# Altium schematic sheet NanoD1_Audio.SchDoc
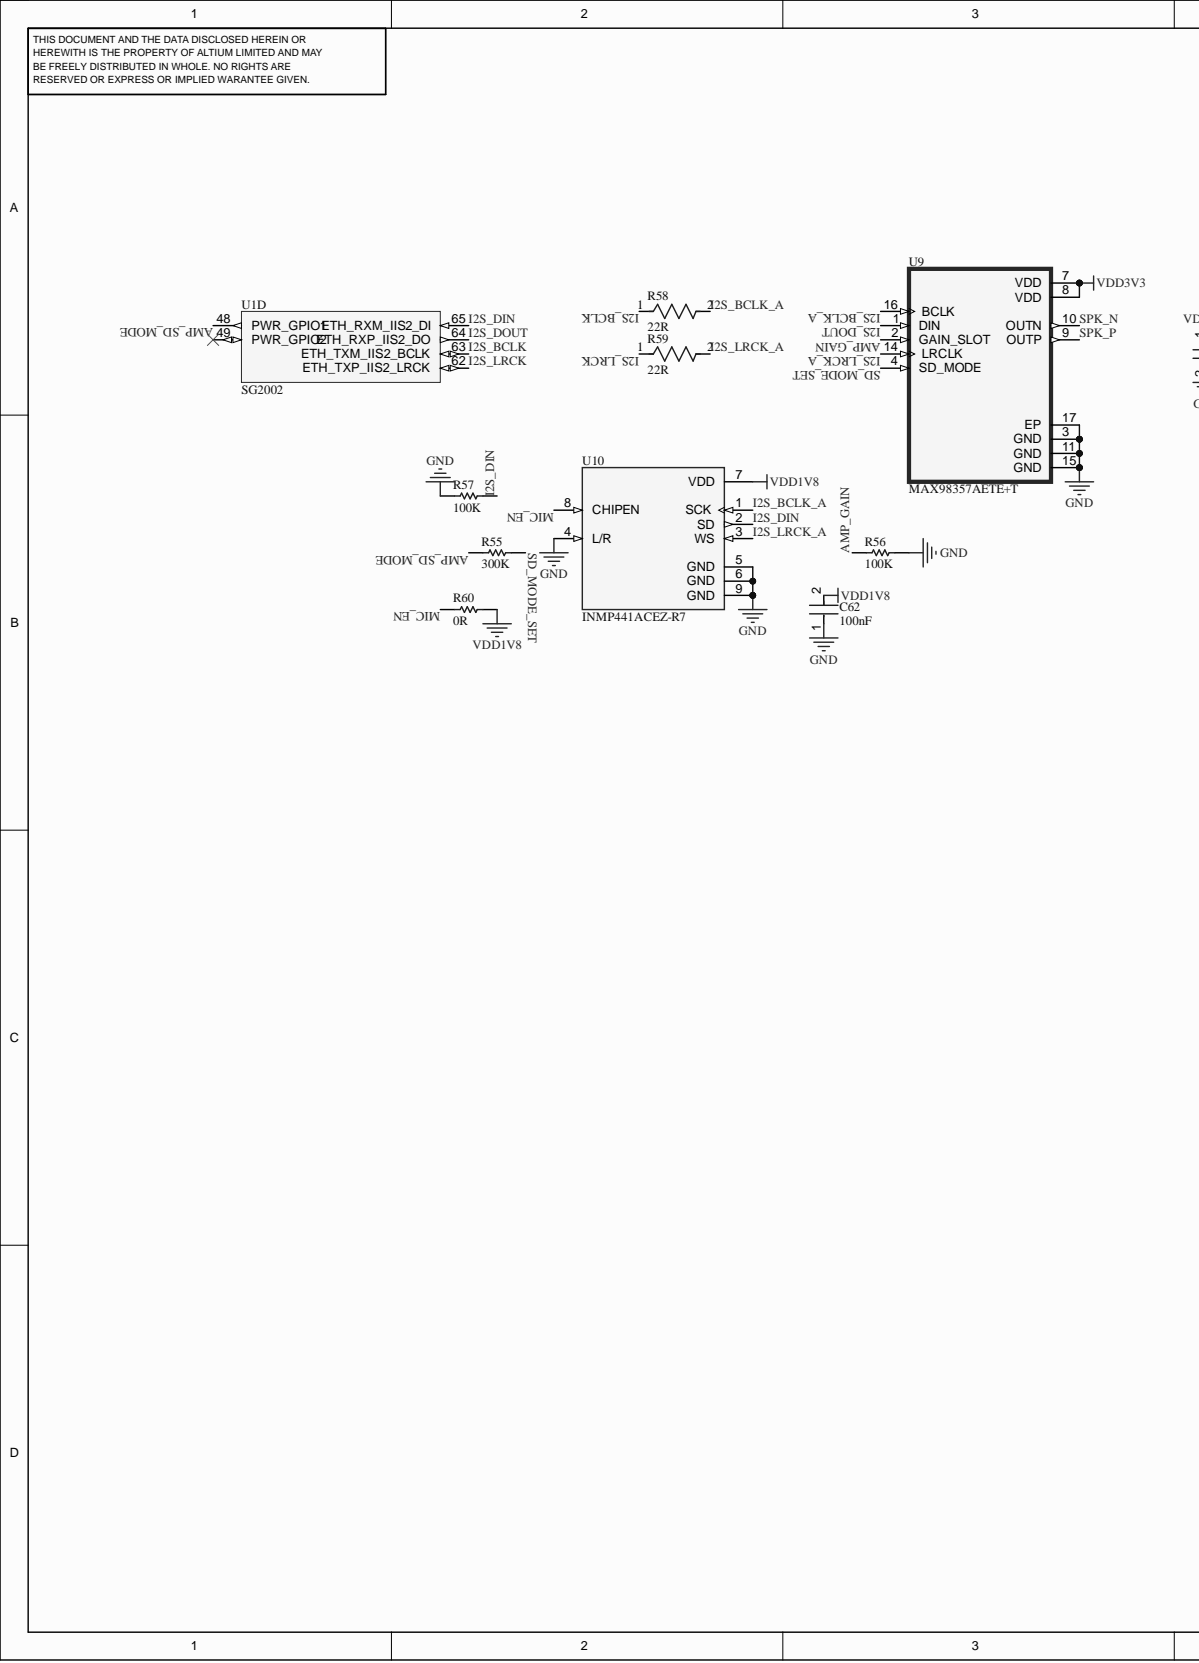
[diagram p0: NanoD1_Audio.SchDoc, left]
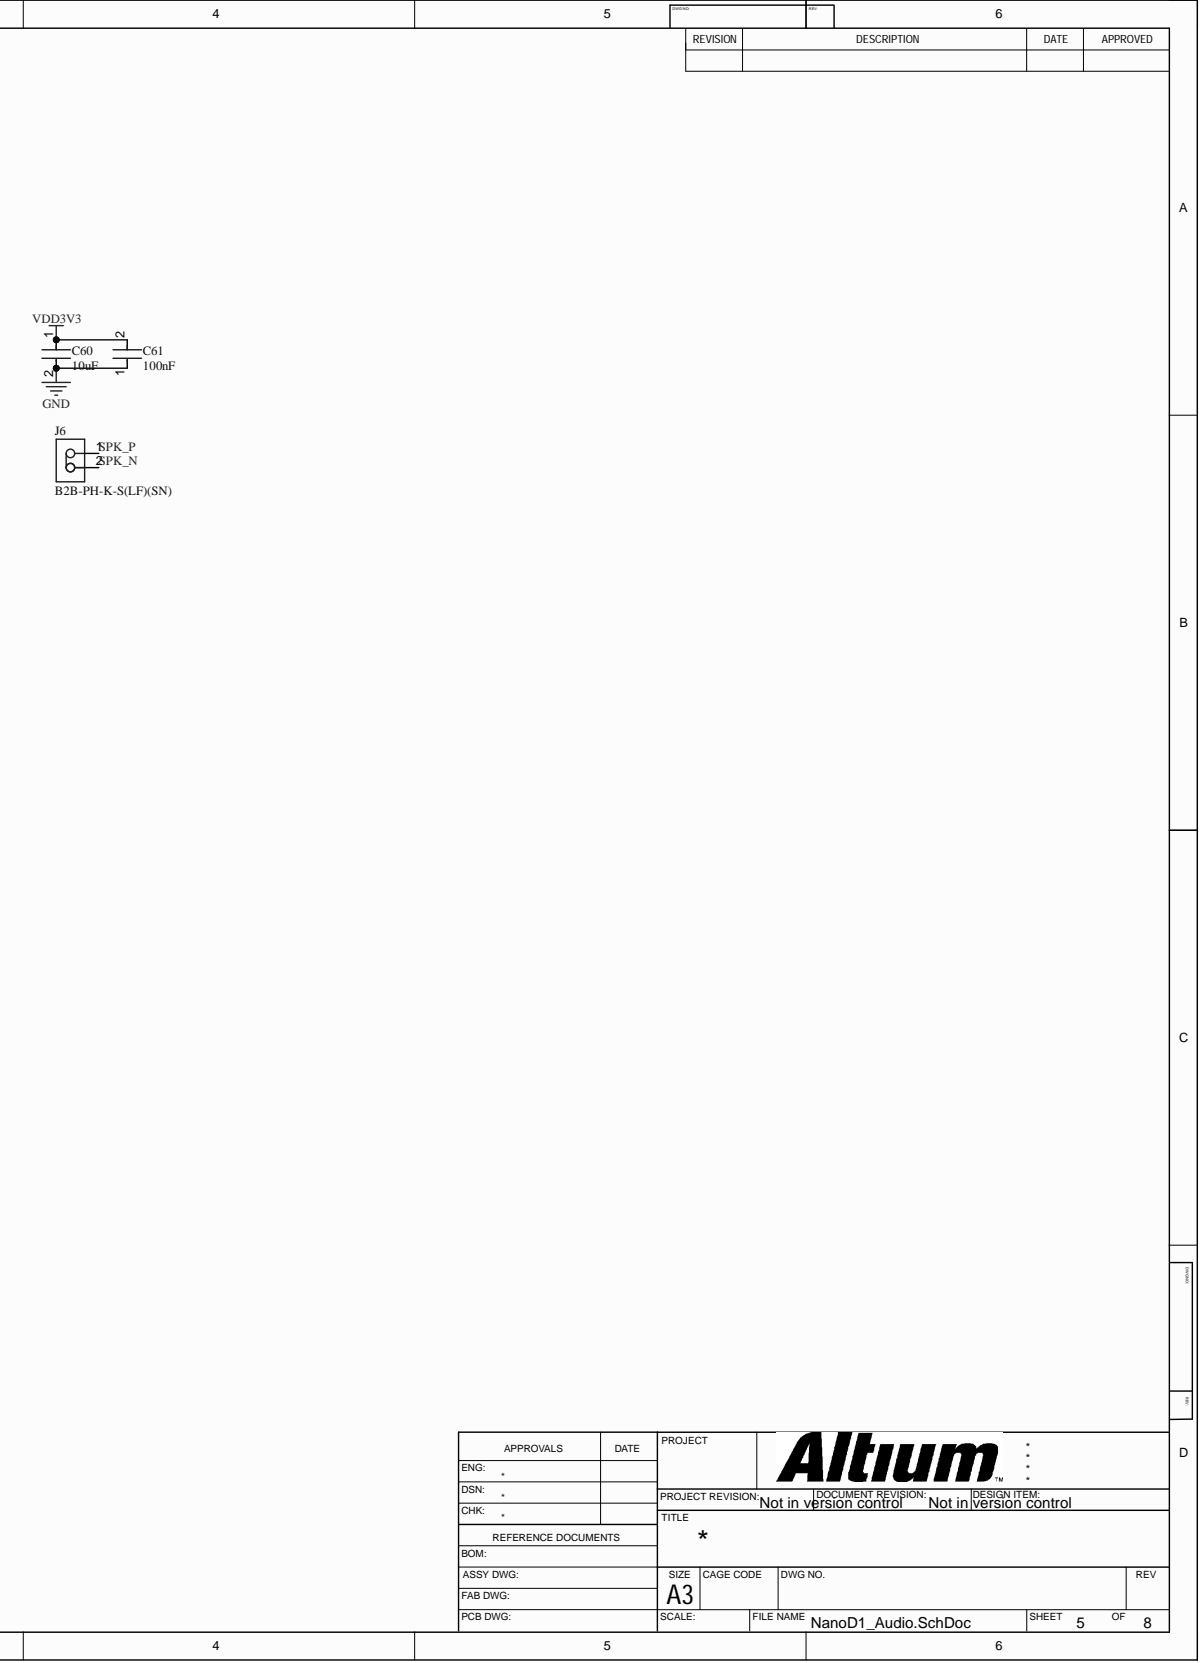
[diagram p1: NanoD1_Audio.SchDoc, right]

## Parts
C60  10uF  [FP-GRM188-0_15-IPC_B]
C61  100nF  [FP-GRM15-MFG]
C62  100nF  [FP-GRM15-MFG]
J6  B2B-PH-K-S(LF)(SN)  [JST-B2B-PH-K-S_V]
R55  300K  [RESC1005X40X25ML05T10]
R56  100K  [RESC1005X40X25ML05T10]
R57  100K  [RESC1005X40X25ML05T10]
R58  22R  [FP-RC0402-0_4-IPC_A]
R59  22R  [FP-RC0402-0_4-IPC_A]
R60  0R  [RESC1005X40X25ML05T10]
U1  SG2002  [QFN88-9x9-0.35]  (part D)
U9  MAX98357AETE+T  [QFN50P300X300X80-17N]
U10  INMP441ACEZ-R7  [MIC_INMP441ACEZ-R7]

## Nets
GND: C60.2 C61.1 C62.1 R56.2 R57.1 U9.3(GND) U9.11(GND) U9.15(GND) U9.17(EP) U10.4(L/R) U10.5(GND) U10.6(GND) U10.9(GND)
VDD1V8: C62.2 R60.2 U10.7(VDD)
VDD3V3: C60.1 C61.2 U9.7(VDD) U9.8(VDD)
AMP_GAIN: R56.1 U9.2(GAIN_SLOT)
AMP_SD_MODE: R55.1 U1.48(PWR_GPIO1)
I2S_BCLK: R58.1 U1.63(ETH_TXM_IIS2_BCLK)
I2S_BCLK_A: R58.2 U9.16(BCLK) U10.1(SCK)
I2S_DIN: R57.2 U1.65(ETH_RXM_IIS2_DI) U10.2(SD)
I2S_DOUT: U1.64(ETH_RXP_IIS2_DO) U9.1(DIN)
I2S_LRCK: R59.1 U1.62(ETH_TXP_IIS2_LRCK)
I2S_LRCK_A: R59.2 U9.14(LRCLK) U10.3(WS)
MIC_EN: R60.1 U10.8(CHIPEN)
SD_MODE_SET: R55.2 U9.4(SD_MODE)
SPK_N: J6.2 U9.10(OUTN)
SPK_P: J6.1 U9.9(OUTP)

Not connected: U1 49(PWR_GPIO2)

## Library data of the parts
C60 = CMP-06035-003612-1: Chip Multilayer Ceramic Capacitors for General Purpose, 0603, 10uF, X5R, 15%, 20%, 10V; Capacitance: 10uF; Case Code (Imperial): 0603; Case Code (Metric): 1608; Case/Package: 0603; Component Kind: Standard; Depth: 0.8mm; Dielectric: X5R; Height: 0.8mm; Lead Free: Lead Free; Length: 1.6mm; Library Name: GRM188R61A106ME69D.IntLib; Material: Ceramic; Max Operating Temperature: 85°C; Min Operating Temperature: -55°C; Mount: Surface Mount; Package Quantity: 4000; Packaging: Tape and Reel; Termination: SMD/SMT; Thickness: 0.035inch; Tolerance: 20%; Voltage Rating: 10V; Voltage Rating (DC): 10V; Weight: 0.000222oz; Width: 0.8mm
C61 C62 = CMP-2100-03641-2: CAP CER 0.1UF 16V X7R 0402; Manufacturer: Murata; Manufacturer Part Number: GRM155R71C104KA88D; Capacitance: 0.10μF; Material: Ceramic; Standoff Height: 0mm; Tolerance: 10%; Value: 0.10μF; Voltage Rating: 16Vdc; Category: Cap; Component Kind: Standard; ComponentLink2Description: Datasheet; ComponentLink2URL: https://search.murata.co.jp/Ceramy/image/img/A01X/G101/ENG/GRM155R71H471KA01-01A.pdf; Device Class L1: Passive Components; Device Class L2: Capacitors; Device Class L3: Ceramic Capacitors; Digikey Description: CAP CER 0.1UF 16V X7R 0402; Height: 0.55mm; Ipc Land Pattern Name: CAPC10050X219; Lead Free: yes; Library Name: GRM155R71C104KA88D.IntLib; Mouser Description: 0402 0.1 uF 16V ±10 % Tolerance X7R SMT Multilayer Ceramic Capacitor; Octopart: https://octopart.com/grm155r71c104ka88d-murata-196880; Package: GRM15; RoHS: TRUE; Temperature: -55°C to +125°C; Temperature Characteristic: X7R; Temperature Range High: +125°C; Temperature Range Low: -55°C
J6 = CMP-2000-05162-1: Male Header, Pitch 2 mm, 1 x 2 Position, Height 6 mm, Tail Length 3.4 mm, -25 to 85 degC, RoHS, Bulk; Manufacturer: JST; No of Positions: 2; Pitch: 2mm; Style/Orientation: StraightHeader/Plug; Component Kind: Standard; Datasheet URL: http://datasheet.octopart.com/B2B-PH-K-S%28LF%29%28SN%29-JST-datasheet-8324864.pdf; Datasheet Version: Nov-08; Library Name: B2B-PH-K-S(LF)(SN).IntLib; Manufacturer URL: http://www.jst.com/home2.html; Termination: Crimp; Type: ShroudedHeader
R55 = CMP-2002-07008-1: Manufacturer: Yageo / Phycomp; Part Number: RC0402FR-07300KL; Component Kind: Standard; Library Name: RC0402FR-07300KL.IntLib
R56 R57 = CMP-2002-07343-1: Manufacturer: Yageo / Phycomp; Part Number: RC0402FR-07100KL; Component Kind: Standard; Library Name: RC0402FR-07100KL.IntLib
R58 R59 = CMP-2002-07520-2: Power Rating: 63mW; Resistance: 22Ω; Temperature Coefficient: 100ppm/°C; Tolerance: 1%; Voltage Rating: 50V; Case Code (Imperial): 0402; Case/Package: 0402; Component Kind: Standard; Composition: Thick Film; Depth: 500µm; Height: 400µm; Lead Free: Lead Free; Length: 1mm; Library Name: RC0402FR-0722RL.IntLib; Max Operating Temperature: 155°C; Military Standard: Not; Min Operating Temperature: -55°C; Number of Pins: 2; Packaging: Tape & Reel; REACH SVHC: Unknown; RoHS: Compliant; Schedule B: 8533210045, 8533210045|8533210045|8533210045|8533210045|8533210045|8533210045|8533210045|8533210045, 8533210045|8533210045|8533210045|8533210045|8533210045|8533210045|8533210045|8533210045|8533210045, 8533210045|8533210045|8533210045|8533210045|8533210045|8533210045|8533210045|8533210045|8533210045|8533210045; Termination: SMD/SMT; Voltage Rating (AC): 50V; Voltage Rating (DC): 50V; Width: 500µm
R60 = CMP-1011-00001-2: Manufacturer: Yageo / Phycomp; Part Number: RC0402JR-070RL; Component Kind: Standard; Library Name: RC0402JR-070RL.IntLib
U1 = SG2002: RISC-V C906 / Cortex-A53 SoC, 256MB DDR3 SiP, QFN88 9x9 0.35mm; Component Kind: Standard; Library Name: NanoD1.SchLib
U9 = MAX98357AETE+T: Tiny, Low-Cost, PCM Class D Amplifier with Class AB Performance; MF: Analog Devices; Check_prices: https://www.snapeda.com/parts/MAX98357AETE+T/Analog+Devices/view-part/?ref=eda; Component Kind: Standard; Library Name: MAX98357AETE_T.IntLib; MP: MAX98357AETE+T; Package: TQFN-16 Maxim; SnapEDA_Link: https://www.snapeda.com/parts/MAX98357AETE+T/Analog+Devices/view-part/?ref=snap
U10 = INMP441ACEZ-R7: 60 Hz ~ 15 kHz Digital, I2S Microphone MEMS (Silicon) 1.62 V ~ 3.63 V Omnidirectional (-26dB Â±3dB @ 94dB SPL) Solder Pads; MF: TDK InvenSense; Check_prices: https://www.snapeda.com/parts/INMP441ACEZ-R7/TDK/view-part/?ref=eda; Component Kind: Standard; Library Name: INMP441ACEZ-R7.IntLib; MP: INMP441ACEZ-R7; Package: LGA-9 TDK InvenSense; SnapEDA_Link: https://www.snapeda.com/parts/INMP441ACEZ-R7/TDK/view-part/?ref=snap
